# Altium schematic sheet Sheet2.SchDoc(Sheet2)
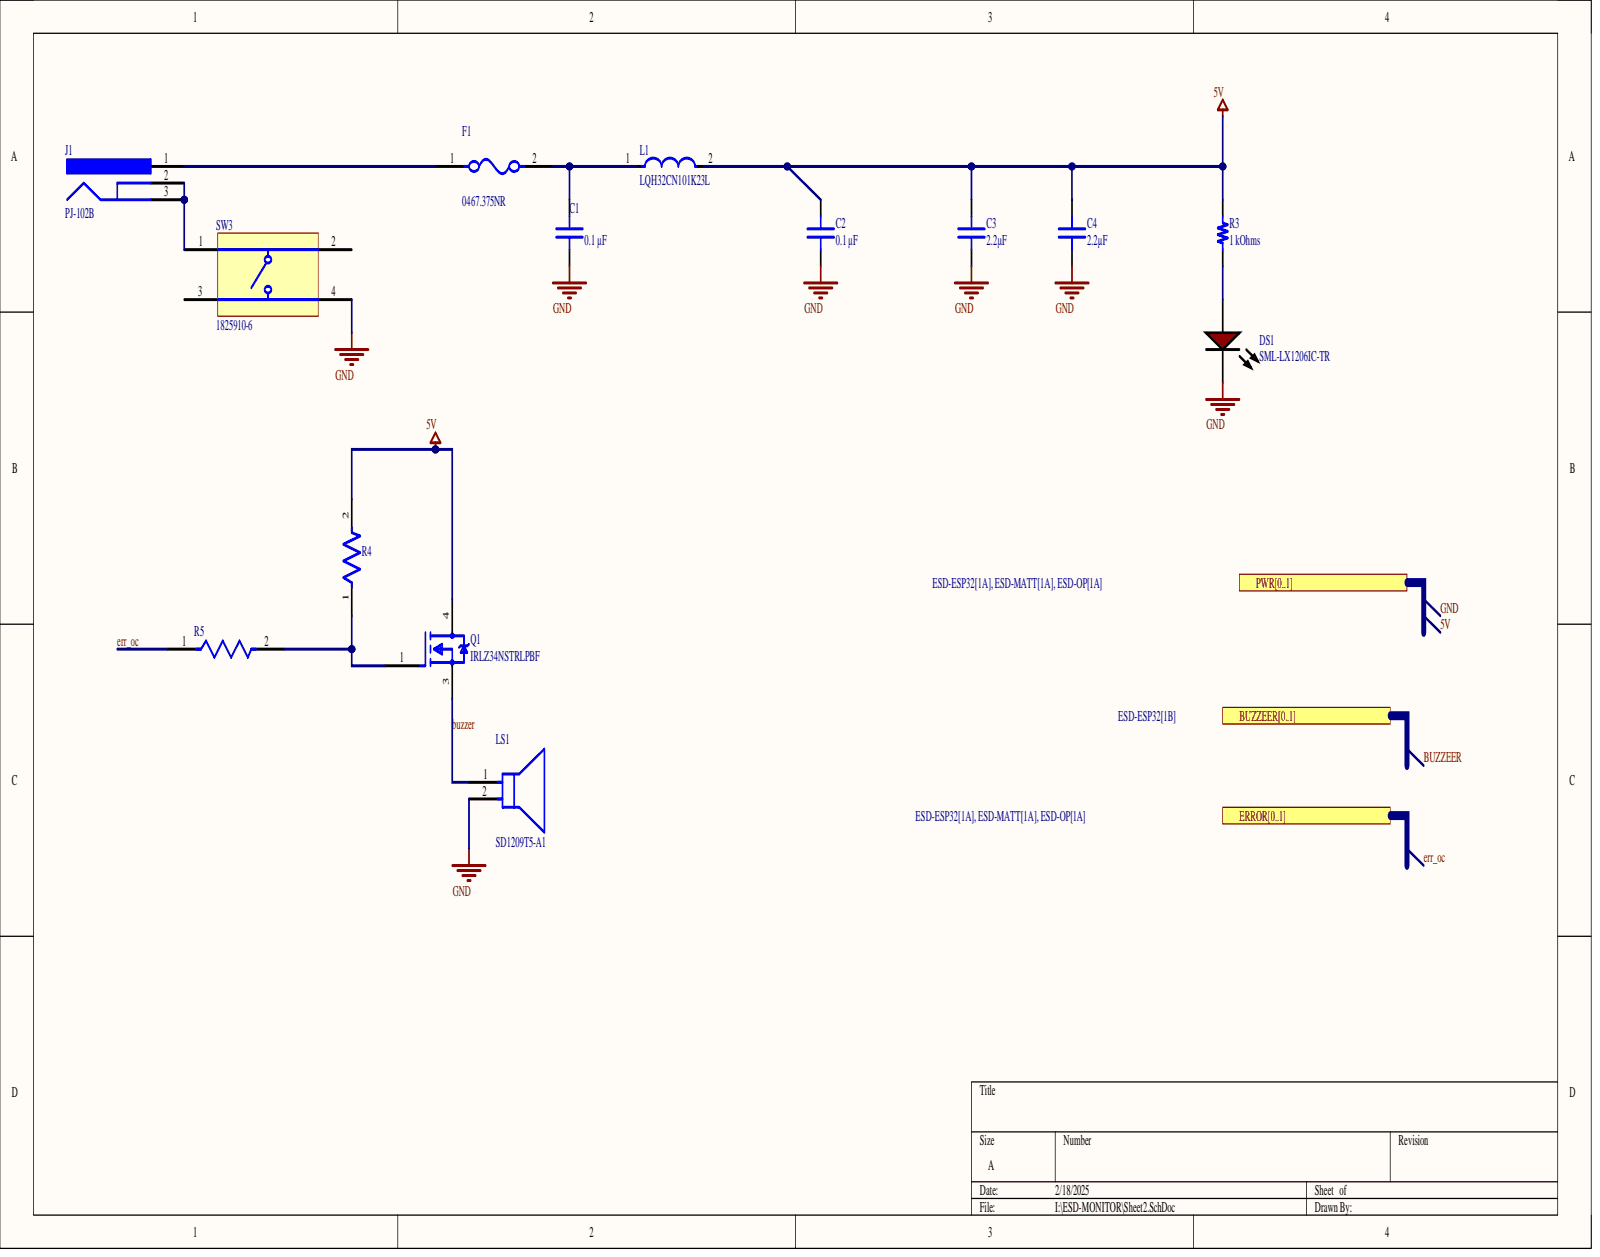
[diagram p0: Sheet2.SchDoc]

## Parts
C1  0.1 µF  [CAP 0603_1608]
C2  0.1 µF  [CAP 0603_1608]
C3  2.2µF  [CAP 0603_1608]
C4  2.2µF  [CAP 0603_1608]
DS1  SML-LX1206IC-TR  [LUMX-SML-LX1206IC_V]
F1  0467.375NR  [FP-467-MFG]
J1  PJ-102B  [CUI-PJ-102B_V]
L1  LQH32CN101K23L  [FP-LQH32CH_23-MFG]
LS1  SD1209T5-A1  [FP-SD1209-MFG]
Q1  IRLZ34NSTRLPBF  [FP-PG-TO263-3-MFG]
R3  1 kOhms  [KOA RES RK73 RN73 0402_1005]
R4  AC0603FR-072KL  [FP-AC0603-IPC_B]  (value not drawn)
R5  AC0603FR-072KL  [FP-AC0603-IPC_B]  (value not drawn)
SW3  1825910-6  [TECO-1825910-6_V]

## Ports
BUZZEER0, BUZZEER1, BUZZEER[0..1], ERROR0, ERROR1, ERROR[0..1], PWR0, PWR1, PWR[0..1]

## Nets
5V: C2.2 C3.2 C4.2 L1.2 Q1.4 R3.2 R4.2
buzzer: LS1.1 Q1.3
err_oc: R5.1
GND: C1.1 C2.1 C3.1 C4.1 DS1.2 LS1.2 SW3.4
NetC1_2: C1.2 F1.2 L1.1
NetDS1_1: DS1.1 R3.1
NetF1_1: F1.1 J1.1
NetJ1_2: J1.2 J1.3 SW3.1
NetQ1_1: Q1.1 R4.1 R5.2
BUZZEER:
N00003_0: ; port ERROR[0..1]
N00003_1: ; port ERROR[0..1]
N00009_0: ; port PWR[0..1]
N00009_1: ; port PWR[0..1]
N00011_0: ; port BUZZEER[0..1]
N00011_1: ; port BUZZEER[0..1]

Not connected: SW3 2 3

## Library data of the parts
C1 C2 = C0603X104J5RACAUTO: CAP CER 0.1UF 50V X7R 0603; manufacturer: KEMET; Database Table Name: Capacitors - Ceramic - 0603; Tolerance: ±5%; Applications: Automotive, Boardflex Sensitive; Capacitance: 0.1 µF; Component Kind: Standard; componentlink1description: Datasheet; componentlink1url: https://api.kemet.com/component-edge/download/specsheet/C0603X104J5RACAUTO.pdf; componentlink2description: DigiKey Link; componentlink2url: https://www.digikey.com/en/products/detail/kemet/C0603X104J5RACAUTO/3314905; Device Package: 0603 (1608 Metric); Features: Soft Termination; lastupdated: 2/9/2024 8:10:26 PM; Library Name: Celestial Altium Library - altium_library.DbLib; Lifecycle Status: Active; Minimum Order: 1; Mounting Type: Surface Mount, MLCC; Operating Temperature: -55°C ~ 125°C; Package / Case: 0603 (1608 Metric); packaging: TapeAndReel; Part Number: C0603X104J5RACAUTO; Part Status: Active; partid: 40909; price: 0.12; Ratings: AEC-Q200; series: SMD Auto X7R Flex; Size / Dimension: 0.063" L x 0.031" W (1.60mm x 0.80mm); Supplier 1: DigiKey; Supplier Part Number 1: 399-6910-1-ND; Temperature Coefficient: X7R; Thickness (Max): 0.037" (0.95mm); Value: 0.1 µF; Voltage - Rated: 50V
C3 C4 = 0603ZC225KAT2A: CAP CER 2.2UF 10V X7R 0603; manufacturer: AVX Corporation; Database Table Name: Capacitors - Ceramic - 0603; Tolerance: ±10%; Applications: General Purpose; Capacitance: 2.2µF; Component Kind: Standard; componentlink1description: Datasheet; componentlink1url: http://datasheets.avx.com/X7RDielectric.pdf; componentlink2description: DigiKey Link; componentlink2url: http://digikey.com/product-detail/en/avx-corporation/0603ZC225KAT2A/478-11618-1-ND/8564176; Device Package: 0603 (1608 Metric); lastupdated: 9/2/2020 1:03:15 AM; Library Name: Celestial Altium Library - altium_library.DbLib; Lifecycle Status: Active; Minimum Order: 1; Mounting Type: Surface Mount, MLCC; Operating Temperature: -55°C ~ 125°C; Package / Case: 0603 (1608 Metric); packaging: TapeAndReel; Part Number: 0603ZC225KAT2A; Part Status: Active; partid: 13741; price: 0.37; series: -; Size / Dimension: 0.063" L x 0.032" W (1.60mm x 0.81mm); Supplier 1: DigiKey; Supplier Part Number 1: 478-11618-1-ND; Temperature Coefficient: X7R; Thickness (Max): 0.037" (0.94mm); Value: 2.2µF; Voltage - Rated: 10V
DS1 = CMP-2000-07123-1: LED Red, 65 mW, -40 to 85 degC, 2-Pin SMD, RoHS, Tape and Reel; Manufacturer: Lumex; Intensity: 6mcd; LED Material: GaAsP; Lens Appearance: ColorlessNonDiffused; Number of LEDs: 1; Peak Wavelength: 635nm; Pin_Count: 2; Reverse Voltage: 5(Min)V; Type: Uni-Color; Viewing Angle: 160; Color: Red; Component Kind: Standard; Datasheet URL: http://www.lumex.com/content/files/ProductAttachment/SML-LX1206IC-TR.pdf; Datasheet Version: Rev. J, 08/2012; Dimension: 3.2x1.6x1.1mm; Dominant Wavelength: N/A; ECCN: EAR99; Family: SML-LX1206IC; HTS: 8541402000; Lens Dimensions: 2x1.6x0.5mm; Library Name: Altium Content Vault; Manufacturer URL: http://www.lumexinstruments.com/; Mounting: SurfaceMount; Mounting Technology: SurfaceMount; Operating Temperature: -25to85C; Package Description: 2-Pin Surface Mount Device, Body 3.2 x 1.5 mm; Package Reference: SML-LX1206IC; Packaging: TapeandReel; Part No: SML-LX1206IC-TR; RoHS: Unknown; Supplier: Lumex; Supplier Standard Pack: 3,000; Supplier_Package: SMD
F1 = CMP-08605-000028-1: FUSE BOARD MOUNT 375MA 32VAC/VDC; Manufacturer: Littelfuse; Fuse Blow Speed: Fast; Fuse Current: 0.375A; Fuse I2T: 0.0035A2Sec; Fuse Interrupt Ac Current: 50A; Max Fuse Ac Voltage: 32V; Automotive: No; Category: Fuse; Component Kind: Standard; ComponentLink2Description: Datasheet; ComponentLink2URL: https://www.littelfuse.com/~/media/electronics/datasheets/fuses/littelfuse_fuse_467_datasheet.pdf.pdf; Device Class L1: Circuit Protection; Device Class L2: Fuses; Device Class L3: unset; Digikey Description: FUSE BOARD MOUNT 375MA 32VAC/VDC; Fuse Interrupt Dc Current: 50A; Height: 0.432mm; Lead Free: Yes; Library Name: Altium Content Vault; Manufacturer Part Number: 0467.375NR; Max Fuse Dc Voltage: 32V; Mouser Description: Surface Mount Fuses 32V.375A VFA Slimline; Octopart: https://octopart.com/0467.375nr-littelfuse-565971; Package: 467; RoHS: TRUE; Temperature: -55°C to +90°C; Temperature Range High: +90°C; Temperature Range Low: -55°C; Voltage Rating: 32V
J1 = CMP-2000-06900-1: Through Hole Right Angle DC Power Jack, 2.5 A, 2.5 mm Center Pin, 3 Position, -25 to 85 degC, RoHS, Bulk; Manufacturer: CUIInc.; Brand: CUI; Current Rating: 2.5A; Inside Contact Diameter: 2.5mm; Insulation Resistance: 100MOhms; Outside Contact Diameter: 6.5mm; Voltage Rating: 24V; Component Kind: Standard; Connector Type: DCPowerJack; Contact Gender: Male; Contact Material: Copper; Contact Plating: Nickel; Datasheet URL: http://www.cui.com/product/resource/pj-102b.pdf; Datasheet Version: Rev. 1.03, 04/2016; Factory Pack Quantity: 280; Flammability Rating: UL94V-0; Housing Material: PolybutyleneTerephthalate(PBT); Library Name: Altium Content Vault; Manufacturer URL: http://www.cui.com/; Mounting Angle: Right; Mounting Style: ThroughHole; Mounting Technology: ThroughHole; Operating Temperature Range: -25Cto+85C; Package Description: 3-Pin Through Hole Device, Body 14.4 x 9 mm, Height 11 mm; Package Reference: PJ-102B; Packaging: Bulk; Product: Jacks; Product Category: DCPowerConnectors; RoHS: True; Termination Style: ThroughHole
L1 = CMP-06042-006648-2: Wire Wound Ferrite Inductor for Power Lines 100μH ±10% 4.55Ω 100mA 1210; Current: 1.1A; DC Resistance (DCR): 3.5R; Frequency: 10MHz; Inductance: 100uH; Q Factor: 40; Shielding: Unshielded; Test Frequency: 1MHz; Case Code (Imperial): 1210; Case Code (Metric): 3225; Case/Package: 1210; Component Kind: Standard; Core Material: Ferrite; Current Rating: 100mA; DC Current: 100mA; Depth: 2.7mm; Height: 2mm; Inductance Tolerance: 10%; Inductor Type: Wirewound; Lead Free: Lead Free; Length: 3.2mm; Library Name: Altium Content Vault; Max DC Current: 100mA; Max Operating Temperature: 85°C; Military Standard: Not; Min Operating Temperature: -40°C; Mount: Surface Mount; Number of Pins: 2; Package Quantity: 2000; Packaging: Tape and Reel; Radiation Hardening: No; Rated Current-Max: 100mA; REACH SVHC: No SVHC; RoHS Compliant: Yes; Self Resonant Frequency: 10MHz; Series: LQH32C_33; Termination: SMD/SMT; Tolerance: 10%; Weight: 0.002116oz; Width: 2.5mm
LS1 = CMP-08413-000009-1: AUDIO MAGNETIC XDCR 3-6V TH; Current Rating: 60mA; Frequency: 2.048kHz; Number of Terminals/Pins: 2; Operating Supply Voltage: 5V; Self Resonant Frequency: 2.05kHz; Washable: No; Component Kind: Standard; Diameter: 12mm; Height: 9mm; Lead Free: Lead Free; Library Name: Altium Content Vault; Max Operating Temperature: 85°C; Max Supply Current: 60mA; Min Operating Temperature: -40°C; Mount: Through Hole; Number of Pins: 2; Operating Supply Current: 60mA; Package Quantity: 100; Packaging: Tray; Sensing Method: Magnetic; Series: SD; Termination: PC Pins; Voltage Rating (DC): 5V
Q1 = CMP-32901-001250-1: MOSFET N-CH 55V 30A D2PAK; Drain to Source Resistance: 60mR; Element Configuration: Single; Gate to Source Voltage (Vgs): 16V; Input Capacitance: 880pF; Max Power Dissipation: 3.8W; Power Dissipation: 68W; Rds On Max: 35mR; Threshold Voltage: 2V; Turn-Off Delay Time: 21ns; Turn-On Delay Time: 8.9ns; Case/Package: D2PAK; Component Kind: Standard; Continuous Drain Current (ID): 30A; Drain to Source Voltage (Vdss): 55V; Halogen Free: Halogen Free; Height: 0.19inch; Lead Free: Contains Lead; Length: 0.42inch; Library Name: Altium Content Vault; Max Dual Supply Voltage: 55V; Max Operating Temperature: 175°C; Min Operating Temperature: -55°C; Mount: Surface Mount; Number of Pins: 3; Packaging: Cut Tape; Reach SVHC Compliant: Yes; RoHS Compliant: Yes; Series: HEXFET®; Width: 0.38inch
R3 = RK73H1ETTPB1001F: RES 1K OHM 1% 1/10W 0402; Manufacturer: KOA Speer Electronics, Inc.; Database Table Name: Resistors - Surface Mount - 0402; Power (Watts): 0.1W, 1/10W; Temperature Coefficient: ±100ppm/°C; Component Kind: Standard; ComponentLink1Description: Datasheet; ComponentLink1URL: https://www.koaspeer.com/pdfs/RK73H.pdf; ComponentLink2Description: DigiKey Link; ComponentLink2URL: https://www.digikey.com/en/products/detail/koa-speer-electronics-inc/RK73H1ETTPB1001F/21680129; Composition: Thick Film; Device Package: 0402 (1005 Metric); Features: Automotive AEC-Q200; Height - Seated (Max): 0.016" (0.40mm); LastUpdated: 7/25/2024 9:41:20 AM; Library Name: Celestial Altium Library - altium_library.DbLib; Lifecycle Status: Active; Minimum Order: 1; Number of Terminations: 2; Operating Temperature: -55°C ~ 155°C; Package / Case: 0402 (1005 Metric); Packaging: CutTape; Part Number: RK73H1ETTPB1001F; PartId: 89462; Price: 0.01; Ratings: AEC-Q200; Resistance: 1 kOhms; Series: RK73H; Size / Dimension: 0.039" L x 0.020" W (1.00mm x 0.50mm); Supplier 1: DigiKey; Supplier Device Package: 0402; Supplier Part Number 1: 2019-RK73H1ETTPB1001FCT-ND; Tolerance: ±1%; Value: 1 kOhms
R4 R5 = CMP-2000-04836-2: RES 2kΩ 1.00% 0.1W 0603; Max Power Dissipation: 100mW; Resistance: 2kR; Temperature Coefficient: 100ppm/°C; Tolerance: 1%; Voltage Rating: 50V; Case Code (Imperial): 0603; Case Code (Metric): 1608; Case/Package: 0603; Component Kind: Standard; Construction: Rectangular; Depth: 0.8mm; Features: Moisture Resistant; Height: 0.45mm; Lead Free: Lead Free; Length: 1.6mm; Library Name: Altium Content Vault; Max Operating Temperature: 155°C; Military Standard: Not; Min Operating Temperature: -55°C; Number of Terminations: 2; Packaging: Tape and Reel; Power Rating: 100mW; Ratings: AEC-Q200; Resistor Type: Thick Film; Series: AC; Size Code: 0603; Termination: SMD/SMT; Width: 0.8mm
SW3 = CMP-1684-00021-1: Tact Switch, SPST-NO, 0.05 A, -35 to 85 degC, 4-Pin THD, RoHS, Bulk; Manufacturer: TE Connectivity; Actuator Color: Black; Actuator Length: 1.4mm; Actuator Material: PolybutyleneTerephthalate; Actuator Style: RoundButton; Body Orientation: Straight; Bushing Type: Unthreaded; Contact Rating @ Voltage: 0.05A@24VDC; Dielectric Strength: 500VAC; Electrical Life: 100000Cycles; Function: MomentaryContact; Illumination: N/R; Maximum Contact Resistance: 100mOhm; Maximum DC Voltage Rating: 24V; Pole Throw Configuration: SPST; Switch Configuration: OFF(ON); Base Material: N/A; Component Kind: Standard; Contact Material: CopperAlloy; Contact Plating: Silver; Datasheet URL: http://www.te.com/commerce/DocumentDelivery/DDEController?Action=showdoc&DocId=Customer+Drawing%7F1825910%7FC9%7Fpdf%7FEnglish%7FENG_CD_1825910_C9.pdf%7F1825910-6; Datasheet Version: Rev. C9; Dimension: 5.99x5mm; Family: 1825910; Housing Material: N/A; Library Name: Altium Content Vault; Manufacturer URL: http://www.te.com; Maximum AC Voltage Rating: N/A; Mechanical Life: 100000Cycles; Minimum Insulation Resistance: 100MOhm; Mounting: ThroughHole; Operating Temperature: -35to85C; Package Description: 4-Pin Through Hole Device, Body 5.99 x 5.99 mm, Pitch 6.5 mm; Package Reference: THD; Packaging: N/A; Part No: 1825910-6; Product Weight: N/A; RoHS: Yes; Seal Description: N/A; Seal Location: N/A; Terminal Type: PCPins; Thread Size: N/R
